# Altium schematic sheet 4.CAMERA-RGB.SchDoc(4.CAMERA-RGB)
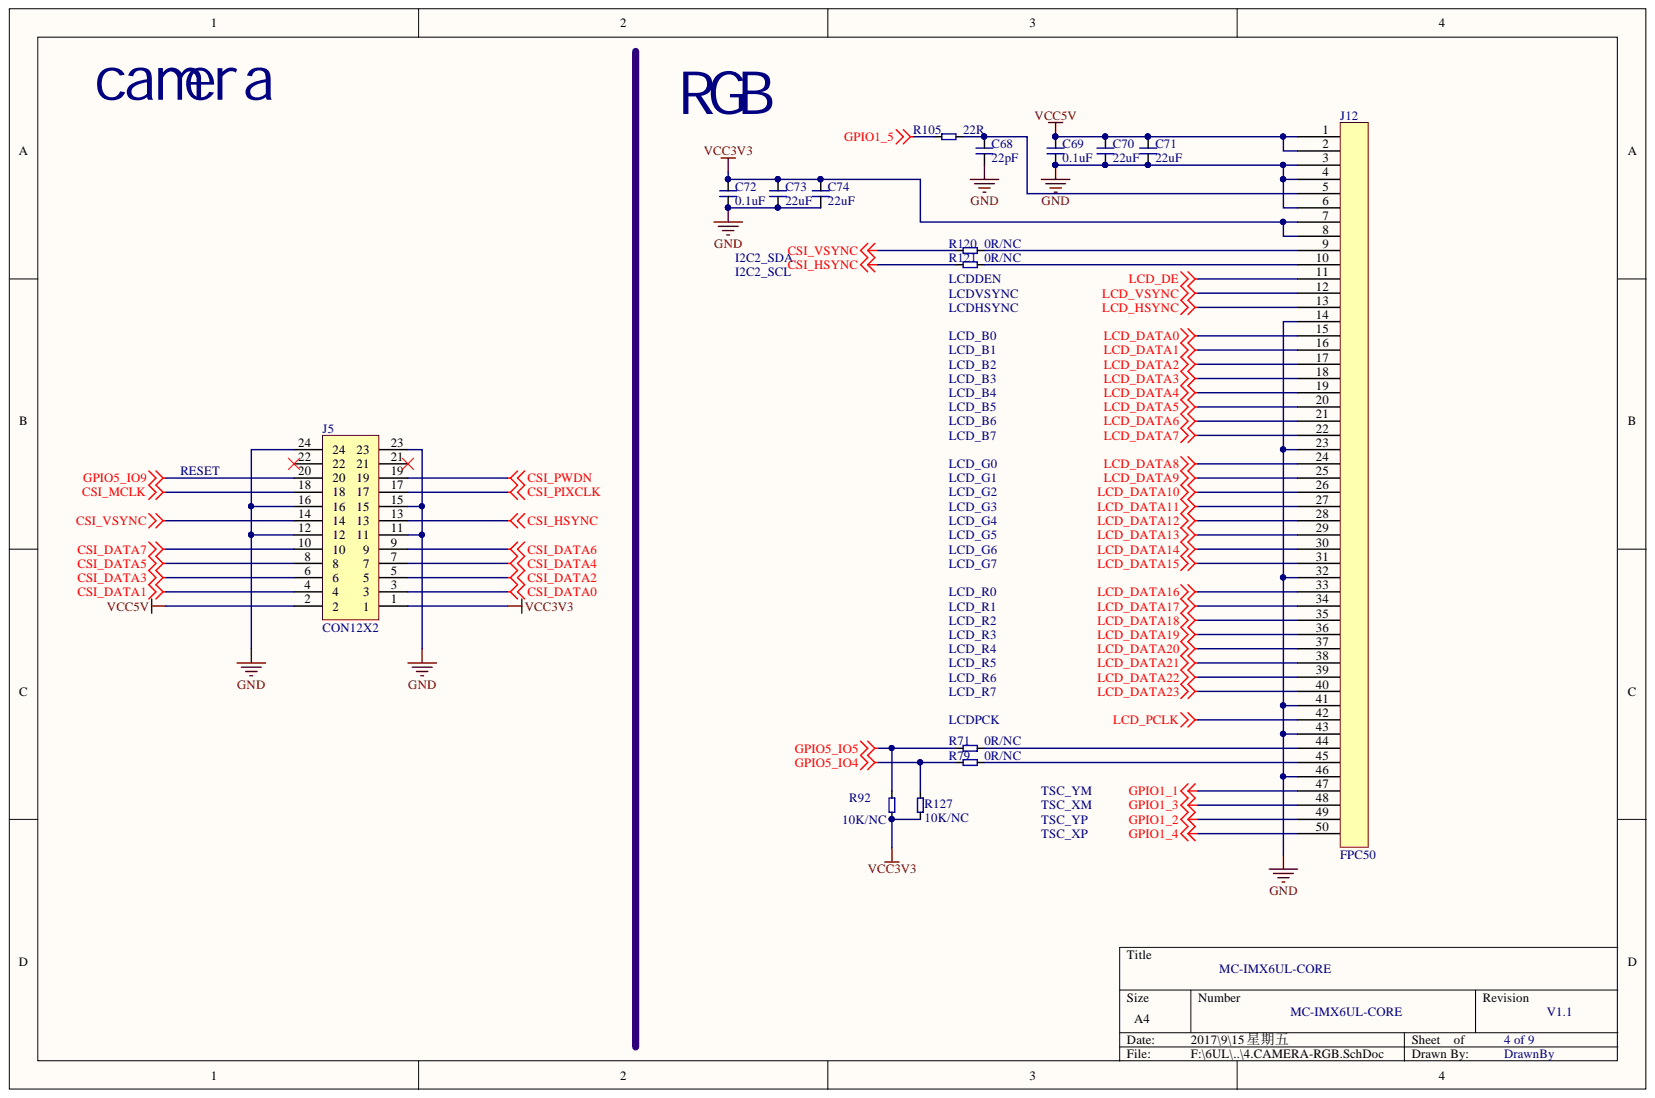
[diagram p0: 4.CAMERA-RGB.SchDoc]

## Parts
C68  22pF  [0603C]
C69  0.1uF  [0603C]
C70  22uF  [0805C]
C71  22uF  [0805C]
C72  0.1uF  [0603C]
C73  22uF  [0805C]
C74  22uF  [0805C]
J5  CON12X2  [IDC12X2-2.54MM]
J12  FPC50  [FPC50-0.5-UP]
R71  0R/NC  [0603R]
R79  0R/NC  [0603R]
R92  10K/NC  [0603R]
R105  22R  [0603R]
R120  0R/NC  [0603R]
R121  0R/NC  [0603R]
R127  10K/NC  [0603R]

## Nets
CSI_DATA0: J5.3
CSI_DATA1: J5.4
CSI_DATA2: J5.5
CSI_DATA3: J5.6
CSI_DATA4: J5.7
CSI_DATA5: J5.8
CSI_DATA6: J5.9
CSI_DATA7: J5.10
CSI_HSYNC: J5.13 R121.2
CSI_MCLK: J5.18
CSI_PIXCLK: J5.17
CSI_PWDN: J5.19
CSI_VSYNC: J5.14 R120.2
GND: C68.2 C69.2 C70.1 C71.1 C72.2 C73.1 C74.1 J5.11 J5.12 J5.15 J5.16 J5.23 J5.24 J12.3 J12.4 J12.6 J12.14 J12.23 J12.32 J12.41 J12.43 J12.46
GPIO1_1: J12.47
GPIO1_2: J12.49
GPIO1_3: J12.48
GPIO1_4: J12.50
GPIO1_5: R105.1
GPIO5_IO4: R79.2 R127.1
GPIO5_IO5: R71.2 R92.1
GPIO5_IO9: J5.20
LCD_DATA0: J12.15
LCD_DATA1: J12.16
LCD_DATA2: J12.17
LCD_DATA3: J12.18
LCD_DATA4: J12.19
LCD_DATA5: J12.20
LCD_DATA6: J12.21
LCD_DATA7: J12.22
LCD_DATA8: J12.24
LCD_DATA9: J12.25
LCD_DATA10: J12.26
LCD_DATA11: J12.27
LCD_DATA12: J12.28
LCD_DATA13: J12.29
LCD_DATA14: J12.30
LCD_DATA15: J12.31
LCD_DATA16: J12.33
LCD_DATA17: J12.34
LCD_DATA18: J12.35
LCD_DATA19: J12.36
LCD_DATA20: J12.37
LCD_DATA21: J12.38
LCD_DATA22: J12.39
LCD_DATA23: J12.40
LCD_DE: J12.11
LCD_HSYNC: J12.13
LCD_PCLK: J12.42
LCD_VSYNC: J12.12
VCC3V3: C72.1 C73.2 C74.2 J5.1 J12.7 J12.8 R92.2 R127.2
VCC5V: C69.1 C70.2 C71.2 J5.2 J12.1 J12.2
NetC68_1: C68.1 J12.5 R105.2
NetJ12_9: J12.9 R120.1
NetJ12_10: J12.10 R121.1
NetJ12_44: J12.44 R71.1
NetJ12_45: J12.45 R79.1

Not connected: J5 21 22

## Library data of the parts
C68-C74 = 电容: Component Kind: Standard; Description: 电容
  C68 C69 C72: Library Name: LDT-SCH.SCHLIB
J12 = FPC50: FPC CONNECTOR; Component Kind: Standard; Library Name: LDT-SCH.SCHLIB
R71 R79 R92 R105 R120 R121 R127 = 电阻: Component Kind: Standard; Description: 电阻; Library Name: LDT-SCH.SCHLIB
J5 = CON12X2: Component Kind: Standard; Library Name: Schlib1.SchLib
